# Altium schematic sheet POwer.SchDoc
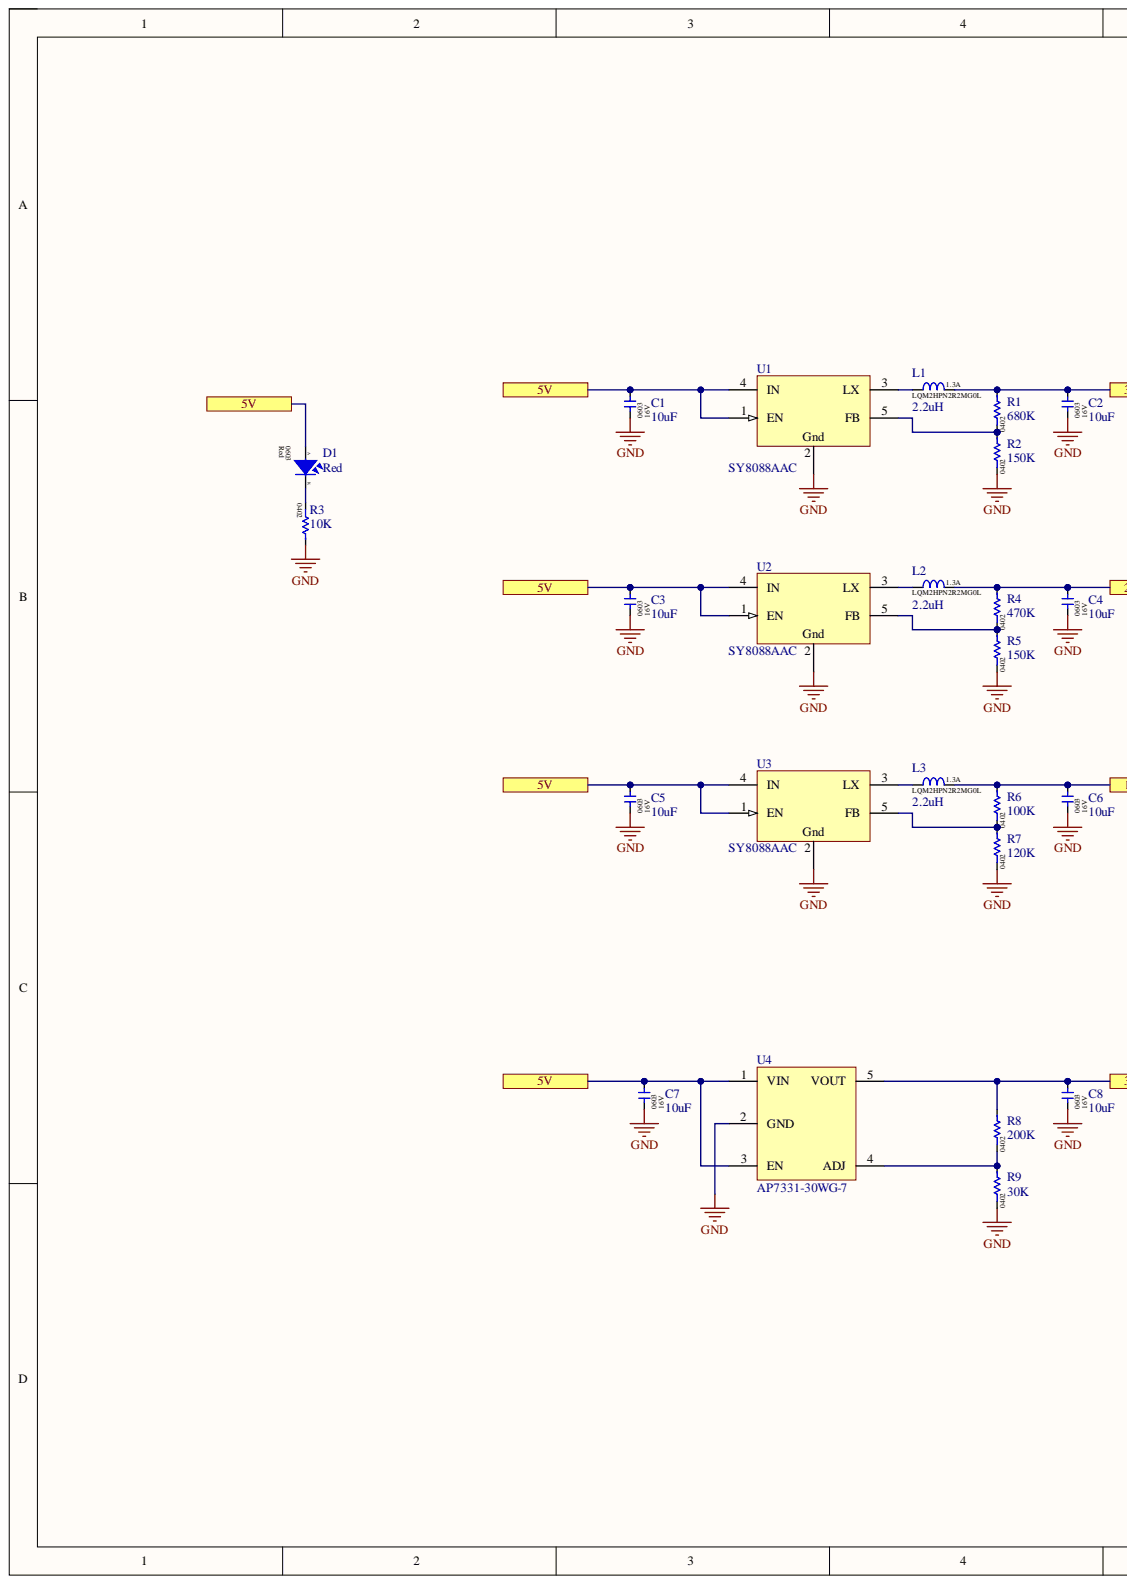
[diagram p0: POwer.SchDoc, left]
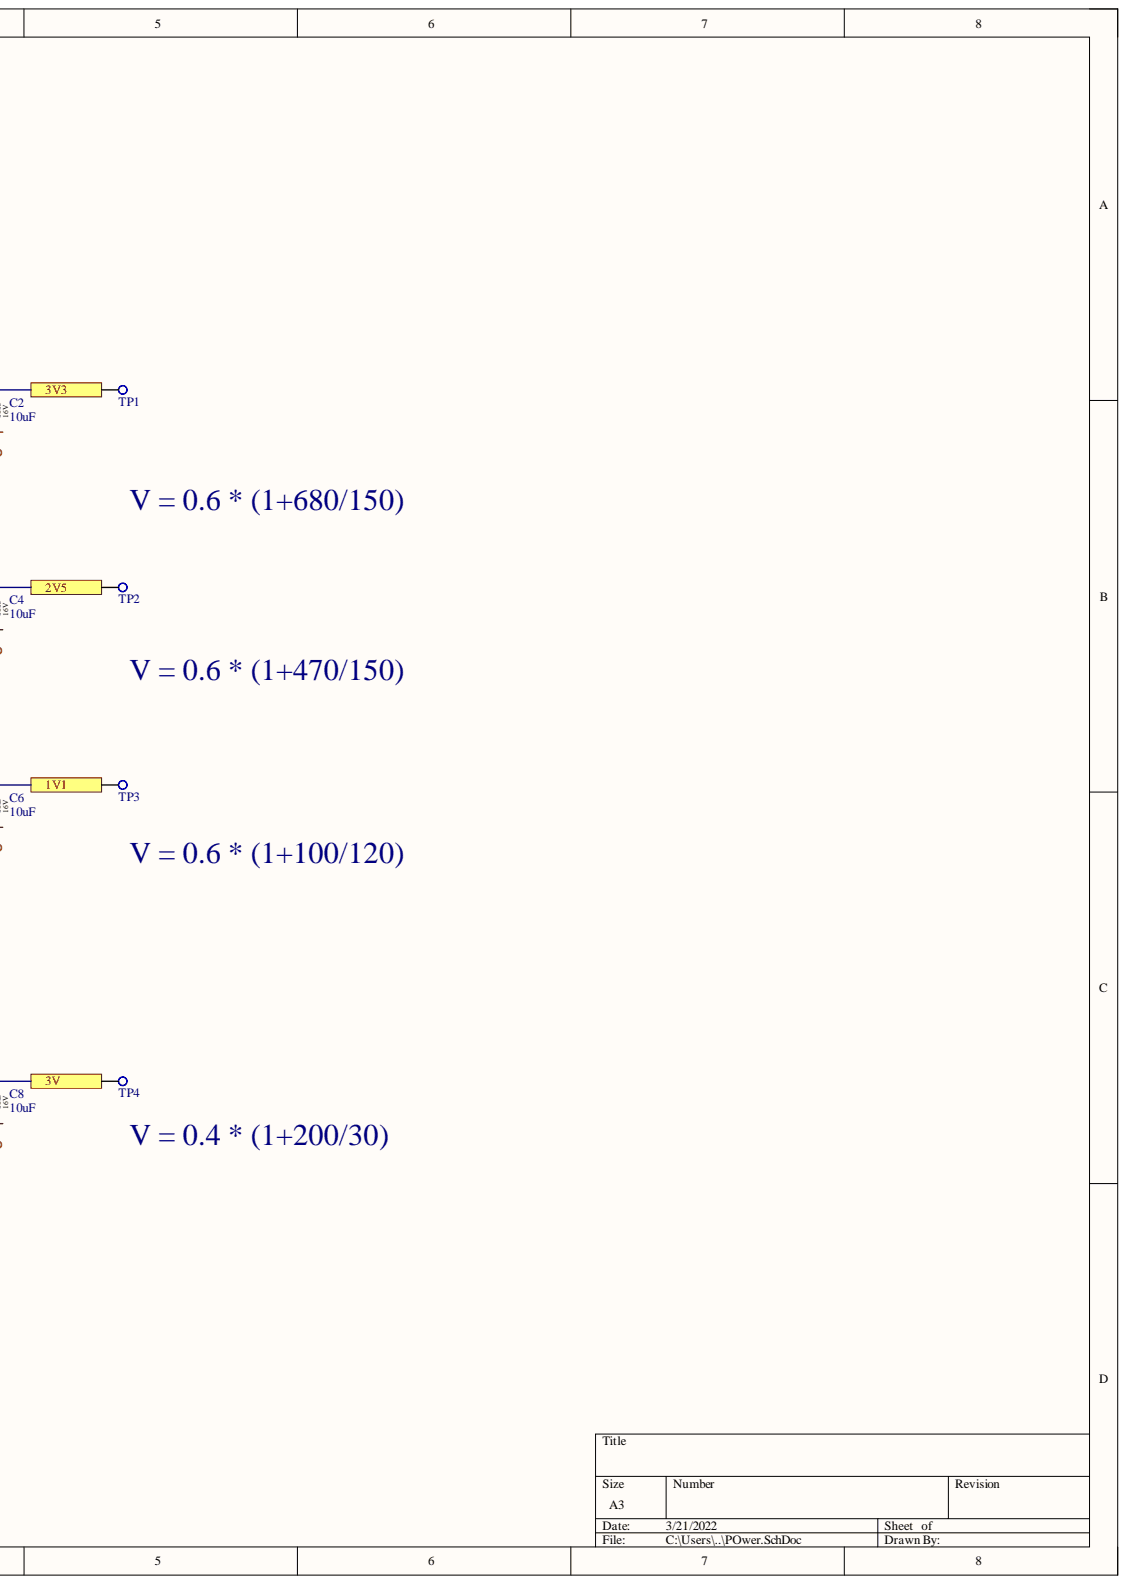
[diagram p1: POwer.SchDoc, right]

## Parts
C1  10uF  Package: 0603  Voltage: 16V  [CAP-0603]
C2  10uF  Package: 0603  Voltage: 16V  [CAP-0603]
C3  10uF  Package: 0603  Voltage: 16V  [CAP-0603]
C4  10uF  Package: 0603  Voltage: 16V  [CAP-0603]
C5  10uF  Package: 0603  Voltage: 16V  [CAP-0603]
C6  10uF  Package: 0603  Voltage: 16V  [CAP-0603]
C7  10uF  Package: 0603  Voltage: 16V  [CAP-0603]
C8  10uF  Package: 0603  Voltage: 16V  [CAP-0603]
D1  Red  Package: 0603  [LED-0603 RED]
L1  2.2uH  Irms max: 1.3A  [IND-1008]
L2  2.2uH  Irms max: 1.3A  [IND-1008]
L3  2.2uH  Irms max: 1.3A  [IND-1008]
R1  680K  Package: 0402  [RES-0402]
R2  150K  Package: 0402  [RES-0402]
R3  10K  Package: 0402  [RES-0402]
R4  470K  Package: 0402  [RES-0402]
R5  150K  Package: 0402  [RES-0402]
R6  100K  Package: 0402  [RES-0402]
R7  120K  Package: 0402  [RES-0402]
R8  200K  Package: 0402  [RES-0402]
R9  30K  Package: 0402  [RES-0402]
TP1  N/A  [Test point]  (value not drawn)  (no BOM)
TP2  N/A  [Test point]  (value not drawn)  (no BOM)
TP3  N/A  [Test point]  (value not drawn)  (no BOM)
TP4  N/A  [Test point]  (value not drawn)  (no BOM)
U1  SY8088AAC  [SOT23-5]
U2  SY8088AAC  [SOT23-5]
U3  SY8088AAC  [SOT23-5]
U4  AP7331-30WG-7  [SOT23-5]

## Ports
1V1, 2V5, 3V, 3V3, 5V

## Nets
GND: C1.1 C2.1 C3.1 C4.1 C5.1 C6.1 C7.1 C8.1 R2.1 R3.2 R5.1 R7.1 R9.1 U1.2 U2.2 U3.2 U4.2(GND)
1V1: C6.2 L3.2 R6.2 TP3.1 ; port 1V1
2V5: C4.2 L2.2 R4.2 TP2.1 ; port 2V5
3V: C8.2 R8.2 TP4.1 U4.5(VOUT) ; port 3V
3V3: C2.2 L1.2 R1.2 TP1.1 ; port 3V3
5V: C1.2 C3.2 C5.2 C7.2 D1.2 U1.1(EN) U1.4(IN) U2.1(EN) U2.4(IN) U3.1(EN) U3.4(IN) U4.1(VIN) U4.3(EN) ; port 5V
NetD1_1: D1.1 R3.1
NetL1_1: L1.1 U1.3(LX)
NetL2_1: L2.1 U2.3(LX)
NetL3_1: L3.1 U3.3(LX)
NetR1_1: R1.1 R2.2 U1.5(FB)
NetR4_1: R4.1 R5.2 U2.5(FB)
NetR6_1: R6.1 R7.2 U3.5(FB)
NetR8_1: R8.1 R9.2 U4.4(ADJ)

## Library data of the parts
C1-C8 = 0603X106M160CT: 16V 10uF X5R ±20% 0603 Multilayer Ceramic Capacitors MLCC - SMD/SMT ROHS; Manufacture: WALSIN; Database Table Name: Sheet1$; Capacitor value: 10uF; Component Kind: Standard; Component type: Capacitor; Library Name: Capacitor Database.DbLib; Manufacture part: 0603X106M160CT; Package / Case: 0402
D1 = 19-217/R6C-AL1M2VY/3T: Red 617.5~633.5nm 0603 Light Emitting Diodes (LED) RoHS; Manufacture: Everlight Elec; Database Table Name: Sheet1$; Color: Red; Component Kind: Standard; Component type: Led; Library Name: Led Database.DbLib; Manufacture part: 19-217/R6C-AL1M2VY/3T; Package / Case: 0402
L1-L3 = LQM2HPN2R2MG0L: Inductor 1008, 2.2±20%, 1.3A; Manufacture: Murata Electronics; Database Table Name: Sheet1$; DCR: 0.1 Ω; Component Kind: Standard; Inductance value: 2.2uH; Library Name: Inductor Database.DbLib; Manufacture part: LQM2HPN2R2MG0L; Package: 1008
R1 = WR04X6803FTL: ±1% 1/16W 50V ±100ppm/℃ -55℃~+155℃ 680kΩ 0402 Chip Resistor - Surface Mount ROHS; Manufacture: WALSIN; Database Table Name: Sheet1$; Component Kind: Standard; Component type: Resistor; Library Name: Resistor Database.DbLib; Manufacture part: WR04X6803FTL; Package / Case: 0201; Resistor value: 680K
R2 R5 = WR04X1503FTL: 150kΩ ±1% 1/16W ±100ppm/℃ 0402 Chip Resistor - Surface Mount RoHS; Manufacture: WALSIN; Database Table Name: Sheet1$; Component Kind: Standard; Component type: Resistor; Library Name: Resistor Database.DbLib; Manufacture part: WR04X1503FTL; Package / Case: 0201; Resistor value: 150K
R3 = WR04X1002FTL: 10kΩ ±1% 1/16W ±100ppm/℃ 0402 Chip Resistor - Surface Mount RoHS; Manufacture: WALSIN; Database Table Name: Sheet1$; Component Kind: Standard; Component type: Resistor; Library Name: Resistor Database.DbLib; Manufacture part: WR04X1002FTL; Package / Case: 0201; Resistor value: 10K
R4 = WR04X4703FTL: ±1% 1/16W 50V ±100ppm/℃ -55℃~+155℃ 470kΩ 0402 Chip Resistor - Surface Mount ROHS; Manufacture: WALSIN; Database Table Name: Sheet1$; Component Kind: Standard; Component type: Resistor; Library Name: Resistor Database.DbLib; Manufacture part: WR04X4703FTL; Package / Case: 0201; Resistor value: 470K
R6 = WR04X1003FTL: 100kΩ ±1% 1/16W ±100ppm/℃ 0402 Chip Resistor - Surface Mount RoHS; Manufacture: WALSIN; Database Table Name: Sheet1$; Component Kind: Standard; Component type: Resistor; Library Name: Resistor Database.DbLib; Manufacture part: WR04X1003FTL; Package / Case: 0201; Resistor value: 100K
R7 = WR04X1203FTL: 120kΩ ±1% 1/16W ±100ppm/℃ 0402 Chip Resistor - Surface Mount RoHS; Manufacture: WALSIN; Database Table Name: Sheet1$; Component Kind: Standard; Component type: Resistor; Library Name: Resistor Database.DbLib; Manufacture part: WR04X1203FTL; Package / Case: 0201; Resistor value: 120K
R8 = WR04X2003FTL: 200kΩ ±1% 1/16W ±100ppm/℃ 0402 Chip Resistor - Surface Mount RoHS; Manufacture: WALSIN; Database Table Name: Sheet1$; Component Kind: Standard; Component type: Resistor; Library Name: Resistor Database.DbLib; Manufacture part: WR04X2003FTL; Package / Case: 0201; Resistor value: 200K
R9 = WR04X3002FTL: ±1% 1/16W ±100ppm/℃ 30kΩ 0402 Chip Resistor - Surface Mount ROHS; Manufacture: WALSIN; Database Table Name: Sheet1$; Component Kind: Standard; Component type: Resistor; Library Name: Resistor Database.DbLib; Manufacture part: WR04X3002FTL; Package / Case: 0201; Resistor value: 30K
TP1-TP4 = N/A: A point used for measure.; Database Table Name: Sheet1$; Library Name: Connectors, Misc.DbLib; Manufacture: N/A; Manufacture part: N/A; Type: Test point
U1-U3 = SY8088AAC: 5.5V 1A SOT-23-5 DC-DC Converters RoHS; Manufacture: Richtek Tech; Database Table Name: Sheet1$; Component Kind: Standard; Datasheet: https://datasheet.lcsc.com/lcsc/Silergy-Corp-SY8088AAC_C79313.pdf; Library Name: Regulator Database.DbLib; Manufacture part: SY8088AAC; Package: SOT23-5; Type: Buck
U4 = AP7331-30WG-7: Fixed 6V 3V 550mV @ 300mA SOT-25 Dropout Regulators(LDO) RoHS; Manufacture: Diodes Incorporated; Part number: AP7331-WG-7; Database Table Name: Sheet1$; Component Kind: Standard; Datasheet: https://datasheet.lcsc.com/lcsc/Diodes-Incorporated-AP7331-WG-7_C212322.pdf; Library Name: Regulator Database.DbLib; Manufacture part: AP7331-30WG-7; Package: SOT23-5; Type: LDO
